# Altium schematic sheet Power.SchDoc
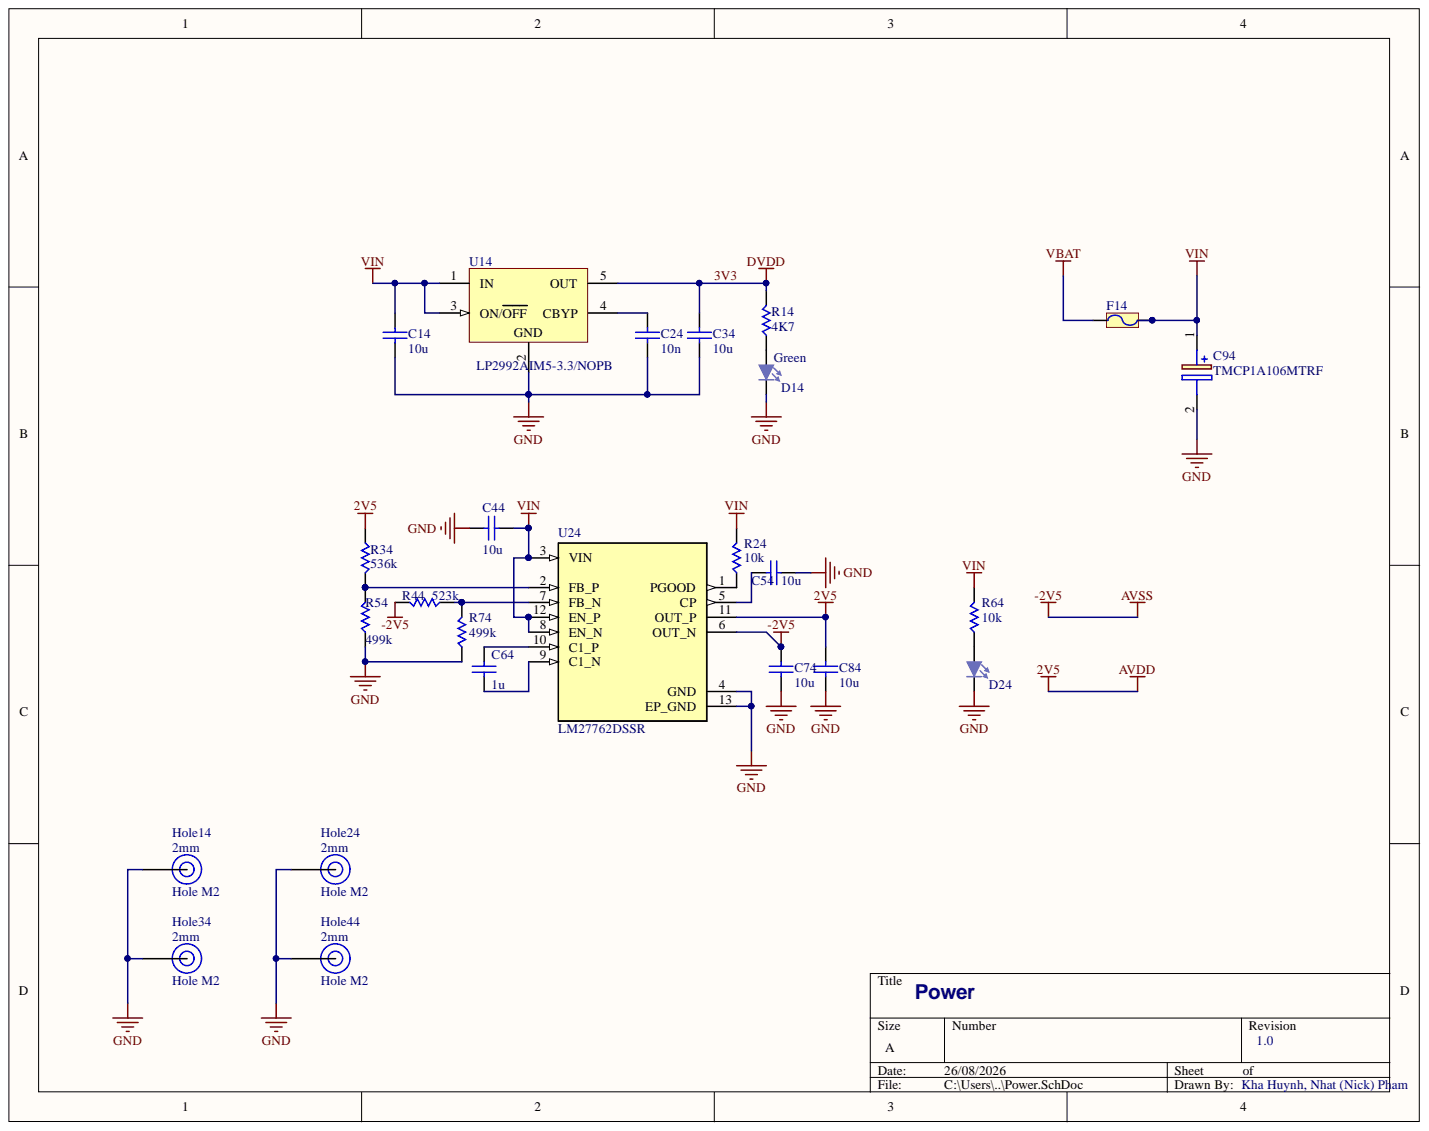
[diagram p0: Power.SchDoc]

## Parts
C14  10u  [SM_0603_C]
C24  10n  [0402 capacitor]
C34  10u  [0402 capacitor]
C44  value 10u  [SM_0603_C]  (hidden comment: C_Ceramic)
C54  value 10u  [SM_0603_C]  (hidden comment: C_Ceramic)
C64  value 1u  [0402 capacitor]  (hidden comment: C_Ceramic)
C74  value 10u  [0402 capacitor]  (hidden comment: C_Ceramic)
C84  value 10u  [0402 capacitor]  (hidden comment: C_Ceramic)
C94  TMCP1A106MTRF  [CAPPC2012X120N]
D14  Green  [SM_0603_LED]
D24  LED  [SM_0603_LED]  (value not drawn)
F14  PRG21BC0R6MM1RA  [SM_0805_R]  (value not drawn)
Hole14  Hole M2  [Hole M2]
Hole24  Hole M2  [Hole M2]
Hole34  Hole M2  [Hole M2]
Hole44  Hole M2  [Hole M2]
R14  4K7  [0402 RESISTOR]
R24  R  value 10k  [0402 RESISTOR]
R34  R  value 536k  [0402 RESISTOR]
R44  R  value 523k  [0402 RESISTOR]
R54  R  value 499k  [0402 RESISTOR]
R64  R  value 10k  [0402 RESISTOR]
R74  R  value 499k  [0402 RESISTOR]
U14  LP2992AIM5-3.3/NOPB  [MF05A_N]
U24  LM27762DSSR  [VREG_LM27762DSSR_LM27762DSSR(Primary)]

## Nets
2V5: C84.1 R34.1 U24.11(OUT_P)
-2V5: C74.1 R44.2 U24.6(OUT_N)
GND: C14.1 C24.1 C34.1 C44.2 C54.1 C74.2 C84.2 C94.2 D14.2 D24.2 Hole14.1 Hole24.1 Hole34.1 Hole44.1 R54.1 R74.1 U14.2 U24.4(GND) U24.13(EP_GND)
VBAT: F14.1
VIN: C14.2 C44.1 C94.1 F14.2 R24.2 R64.2 U14.1(IN) U14.3(ON/OFF) U24.3(VIN) U24.8(EN_N) U24.12(EN_P)
3V3: C34.2 R14.2 U14.5(OUT)
NetC24_2: C24.2 U14.4(CBYP)
NetC54_2: C54.2 U24.5(CP)
NetC64_1: C64.1 U24.10(C1_P)
NetC64_2: C64.2 U24.9(C1_N)
NetD14_1: D14.1 R14.1
NetD24_1: D24.1 R64.1
NetR24_1: R24.1 U24.1(PGOOD)
NetR34_2: R34.2 R54.2 U24.2(FB_P)
NetR44_1: R44.1 R74.2 U24.7(FB_N)

## Library data of the parts
C14 C44 C54 = C_Ceramic: Non-polarity ceramic capacitor; Manufacturer Part Number: GRM188R61C106KAALD; Component Kind: Standard; JLCPCB Part #: C86275; LatestRevisionDate: 17-Jul-2002; LatestRevisionNote: Re-released for DXP Platform.; PackageDescription: Radial Cap, Thru-Hole; 2 Leads; 0.3 in Pin Spacing; PackageReference: RAD-0.3; Published: 8-Jun-2000; Library Name: Nhat_Lib.SchLib; Publisher: Altium Limited
  C14: Value: 10u
C24 = C_Ceramic: Non-polarity ceramic capacitor; Manufacturer Part Number: CC0402JRX7R9BB103; Component Kind: Standard; JLCPCB Part #: C272878; LatestRevisionDate: 17-Jul-2002; LatestRevisionNote: Re-released for DXP Platform.; PackageDescription: Radial Cap, Thru-Hole; 2 Leads; 0.3 in Pin Spacing; PackageReference: RAD-0.3; Published: 8-Jun-2000; Value: 10n; Library Name: Nhat_Lib.SchLib; Publisher: Altium Limited
C34 C74 C84 = C_Ceramic: Non-polarity ceramic capacitor; Manufacturer Part Number: GRM155R61A106ME44D; Component Kind: Standard; JLCPCB Part #: C77000; LatestRevisionDate: 17-Jul-2002; LatestRevisionNote: Re-released for DXP Platform.; PackageDescription: Radial Cap, Thru-Hole; 2 Leads; 0.3 in Pin Spacing; PackageReference: RAD-0.3; Published: 8-Jun-2000; Library Name: Nhat_Lib.SchLib; Publisher: Altium Limited
  C34: Value: 10u
C64 = C_Ceramic: Non-polarity ceramic capacitor; Manufacturer Part Number: GRM155R61A105KE15D; Component Kind: Standard; JLCPCB Part #: C76999; LatestRevisionDate: 17-Jul-2002; LatestRevisionNote: Re-released for DXP Platform.; PackageDescription: Radial Cap, Thru-Hole; 2 Leads; 0.3 in Pin Spacing; PackageReference: RAD-0.3; Published: 8-Jun-2000; Library Name: Nhat_Lib.SchLib; Publisher: Altium Limited
C94 = TMCP1A106MTRF: Capacitor Polarised; Manufacturer_Name: Vishay; Arrow Part Number: TMCP1A106MTRF; Arrow Price/Stock: https://www.arrow.com/en/products/tmcp1a106mtrf/vishay?region=nac; Component Kind: Standard; Datasheet Link: https://www.mouser.com/datasheet/2/427/tmcp-541000.pdf; Height: 1.2mm; JLCPCB Part #: C1969735; Manufacturer Part Number: TMCP1A106MTRF; Mouser Part Number: 74-TMCP1A106MTRF; Mouser Price/Stock: https://www.mouser.co.uk/ProductDetail/Vishay-Sprague/TMCP1A106MTRF?qs=WatphXlIDnwgOXALcETXlA%3D%3D; Library Name: KhaHuynhV12.SchLib
D14 = LED: Manufacturer Part Number: SML-310MTT86; Component Kind: Standard; Description: LED; JLCPCB Part #: C122984; LatestRevisionDate: 08-Jul-2005; LatestRevisionNote: Mech Layer 1 removed.; PackageDescription: LED; 2 Leads; PackageReference: LED-1; Published: 8-Jun-2000; Library Name: Nhat_Lib.SchLib; Publisher: Altium Limited
D24 = LED: Manufacturer Part Number: SML-D12V8WT86; Component Kind: Standard; Description: LED; JLCPCB Part #: C2693607; LatestRevisionDate: 08-Jul-2005; LatestRevisionNote: Mech Layer 1 removed.; PackageDescription: LED; 2 Leads; PackageReference: LED-1; Published: 8-Jun-2000; Library Name: Nhat_Lib.SchLib; Publisher: Altium Limited
F14 = Fuse: Component Kind: Standard; JLCPCB Part #: C3762088; Manufacturer Part Number: PRG21BC0R6MM1RA; Library Name: Nhat_Lib.SchLib
R14 = R: Resistor; Manufacturer Part Number: RC0402JR-074K7L; Component Kind: Standard; JLCPCB Part #: C105870; LatestRevisionDate: 29-May-2009; LatestRevisionNote: IPC-7351 Footprint Added.; PackageDescription: Chip Resistor; PackageReference: J1-0603; Published: 8-Jun-2000; Value: 4K7; Library Name: Nhat_Lib.SchLib; Publisher: Altium Limited
R24 = R: Resistor; Manufacturer Part Number: RC0402JR-0710KL; Value: 10k; Component Kind: Standard; JLCPCB Part #: C60489; LatestRevisionDate: 29-May-2009; LatestRevisionNote: IPC-7351 Footprint Added.; PackageDescription: Chip Resistor; PackageReference: J1-0603; Published: 8-Jun-2000; Library Name: Nhat_Lib.SchLib; Publisher: Altium Limited
R34 = R: Resistor; Manufacturer Part Number: RC0402FR-07536KL; Component Kind: Standard; JLCPCB Part #: C327337; LatestRevisionDate: 29-May-2009; LatestRevisionNote: IPC-7351 Footprint Added.; PackageDescription: Chip Resistor; PackageReference: J1-0603; Published: 8-Jun-2000; Library Name: Nhat_Lib.SchLib; Publisher: Altium Limited
R44 = R: Resistor; Manufacturer Part Number: CRCW0402523KFKED; Component Kind: Standard; JLCPCB Part #: C2076639; LatestRevisionDate: 29-May-2009; LatestRevisionNote: IPC-7351 Footprint Added.; PackageDescription: Chip Resistor; PackageReference: J1-0603; Published: 8-Jun-2000; Library Name: Nhat_Lib.SchLib; Publisher: Altium Limited
R54 R74 = R: Resistor; Manufacturer Part Number: RC0402FR-07499KL; Component Kind: Standard; JLCPCB Part #: C137971; LatestRevisionDate: 29-May-2009; LatestRevisionNote: IPC-7351 Footprint Added.; PackageDescription: Chip Resistor; PackageReference: J1-0603; Published: 8-Jun-2000; Library Name: Nhat_Lib.SchLib; Publisher: Altium Limited
R64 = R: Resistor; Manufacturer Part Number: RC0402JR-0710KL; Component Kind: Standard; JLCPCB Part #: C60489; LatestRevisionDate: 29-May-2009; LatestRevisionNote: IPC-7351 Footprint Added.; PackageDescription: Chip Resistor; PackageReference: J1-0603; Published: 8-Jun-2000; Library Name: Nhat_Lib.SchLib; Publisher: Altium Limited
U14 = LP2992AIM5-1.5: Micropower 250 mA Low-Noise Ultra Low-Dropout Regulator, 5-pin SOT-23; Manufacturer: National Semiconductor; CompType: LDO IC; High-Reliability: Y; PackageTopMarking: LFBA*XTT; PowerWise: N; Component Kind: Standard; ComponentLink1Description: Manufacturer URL; ComponentLink1URL: http://www.national.com/; ComponentLink2Description: LP2992 Datasheet; ComponentLink2URL: http://www.national.com/ds/LP/LP2992.pdf; ComponentLink3Description: LP2992 Web Page; ComponentLink3URL: http://www.national.com/pf/LP/LP2992.html; ComponentLink4Description: MF05A Package Page; ComponentLink4URL: http://www.national.com/packaging/folders/mf05a.html; DatasheetVersion: May-2005; JLCPCB Part #: C2860029; Library Name: National Semiconductor LDO.IntLib; Manufacturer Part Number: LP2992AIM5-3.3/NOPB; PackageDescription: Molded SOT-23, .115 x .063 x .040 in Body, 5 Lead, .0375 in Pitch; PackageReference: MF05A; PackageVersion: revD, Aug-2008; PinCount: 5; RoHS: N
U24 = LM27762DSSR: Low-Noise Positive- and Negative-Output Charge Pump With Integrated LDO 12-WSON -40 to 85; MF: Texas Instruments; Availability: Unavailable; Component Kind: Standard; JLCPCB Part #: C473398; Manufacturer Part Number: LM27762DSSR; Package: WSON-12 Texas Instruments; Price: None; SnapEDA_Link: https://www.snapeda.com/parts/LM27762DSSR/Texas%20Instruments/view-part/?ref=snap; Library Name: Nhat_Lib.SchLib
Hole14 Hole24 Hole34 Hole44 = Hole M2: Component Kind: Standard; Library Name: KhaHuynhV12.SchLib
